# Altium schematic sheet icarus2.SchDoc
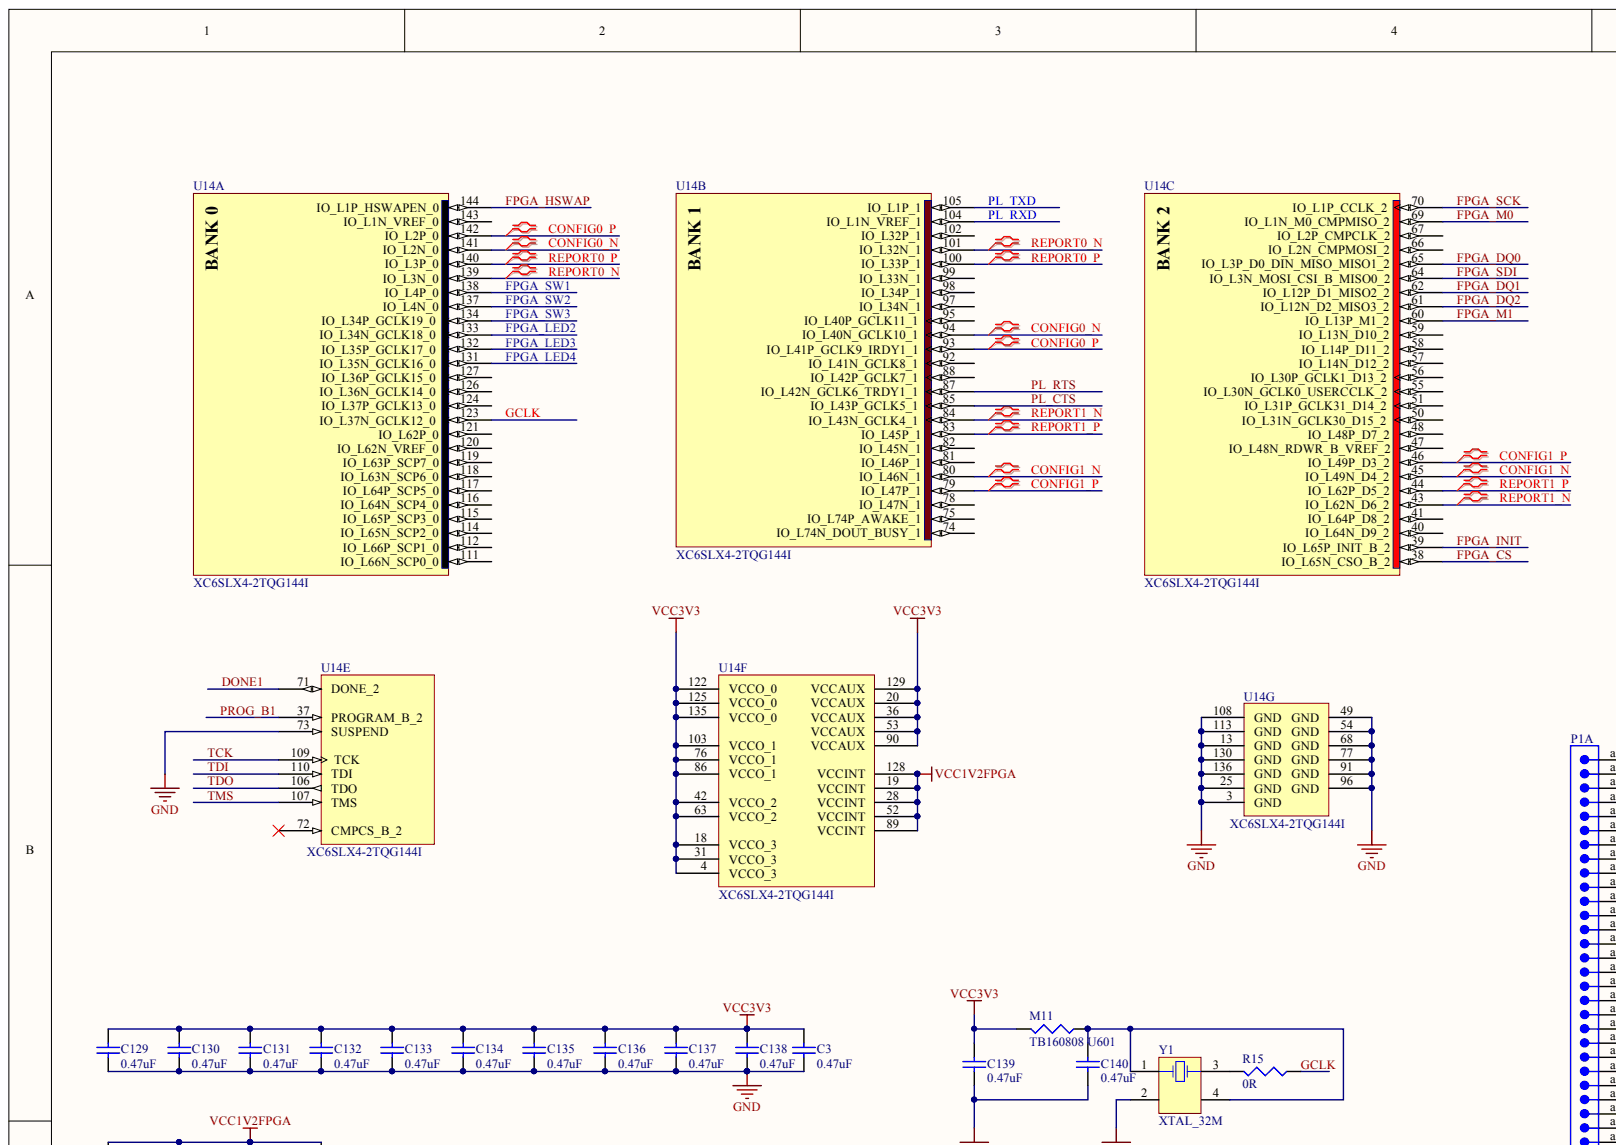
[diagram p0: icarus2.SchDoc, top left]
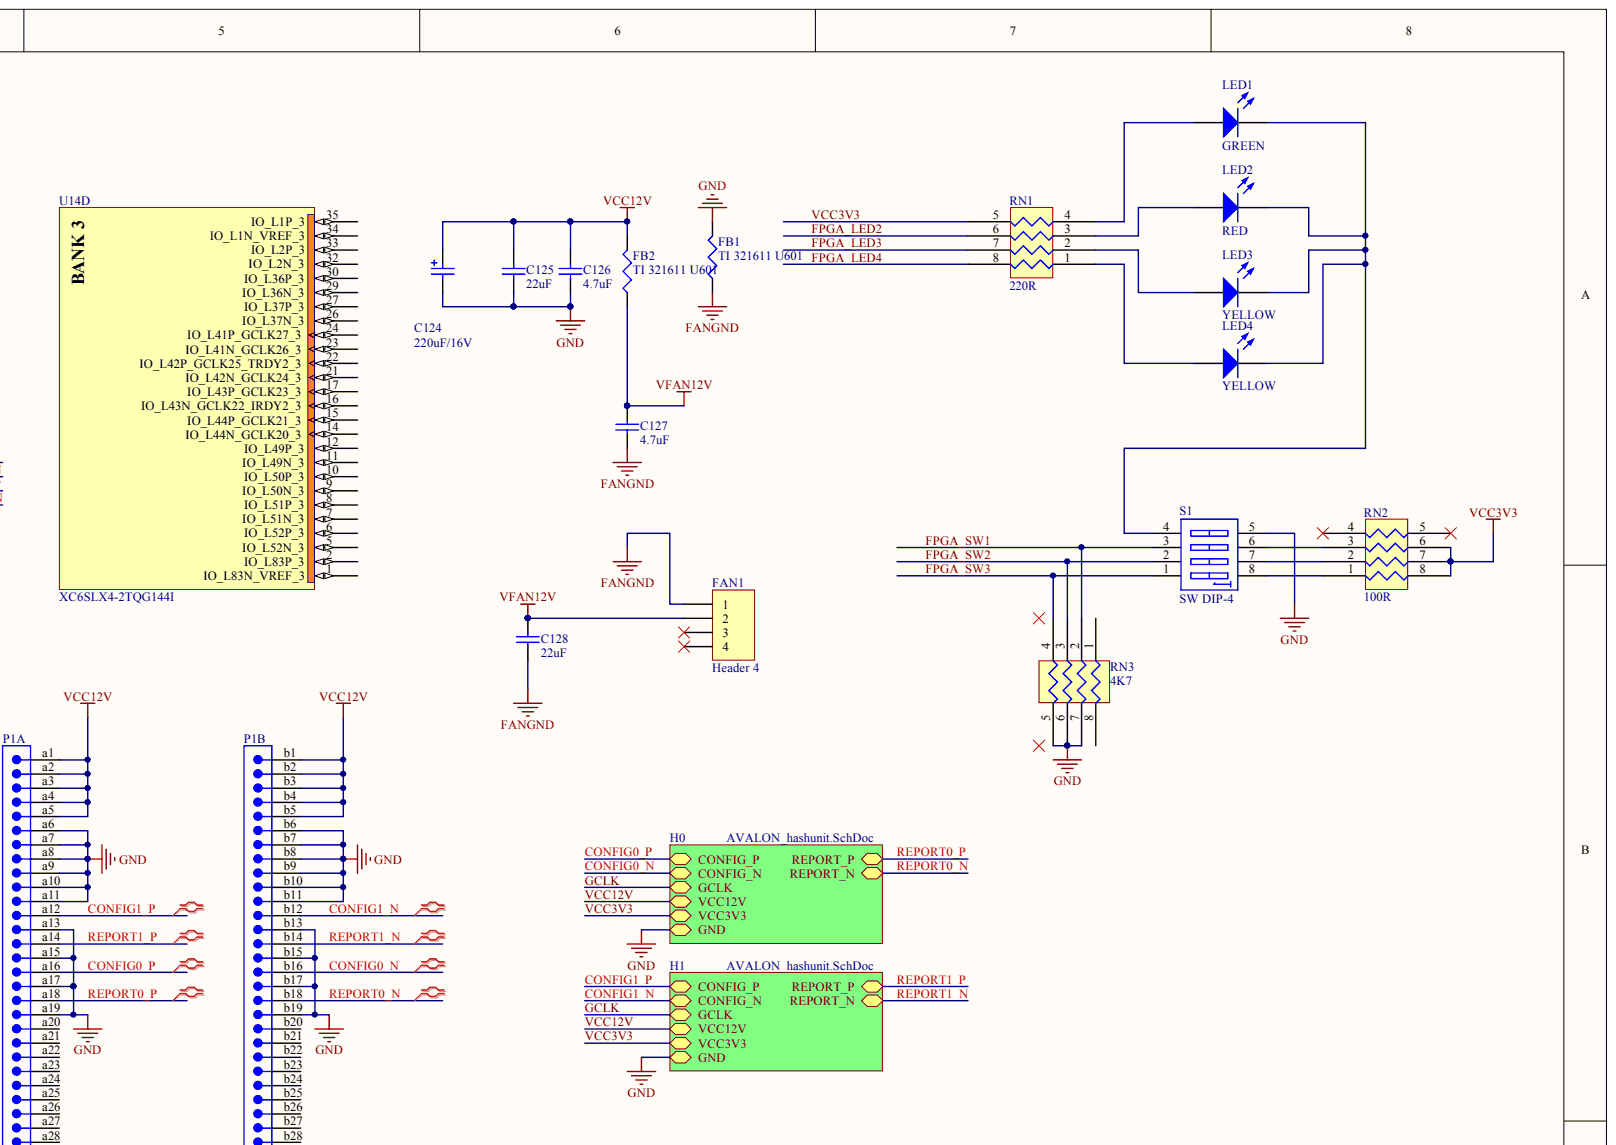
[diagram p1: icarus2.SchDoc, top right]
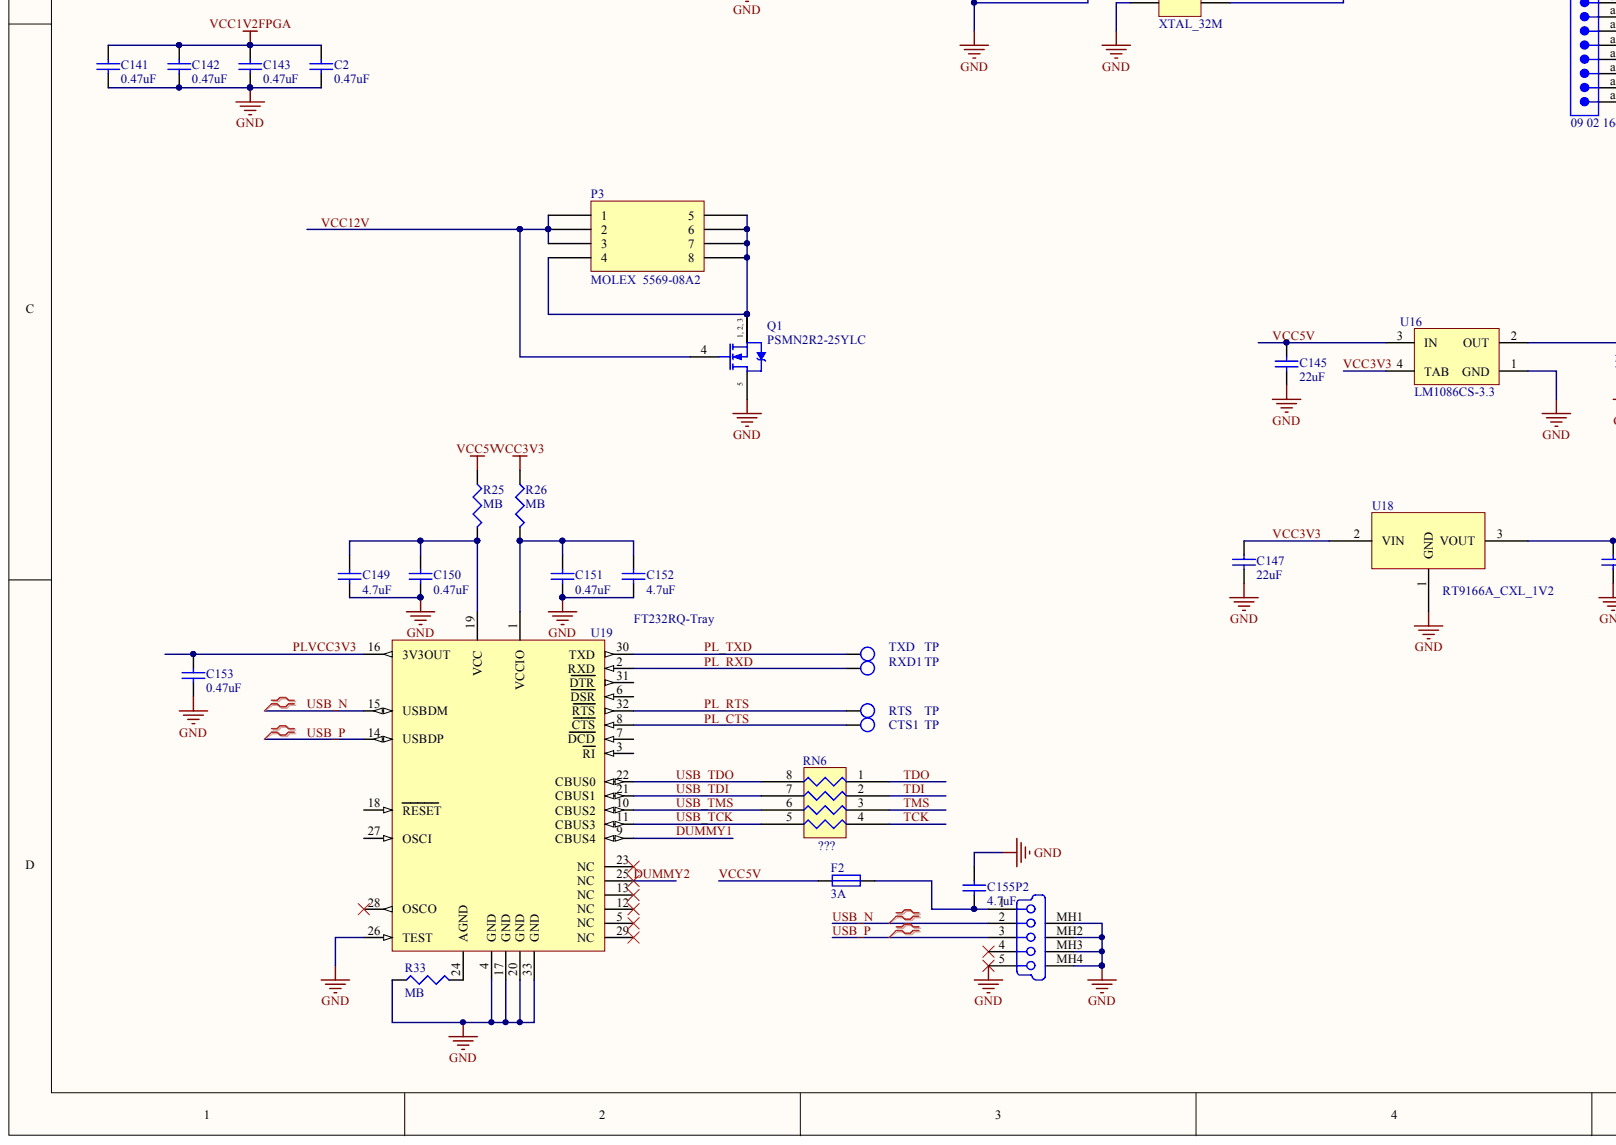
[diagram p2: icarus2.SchDoc, bottom left]
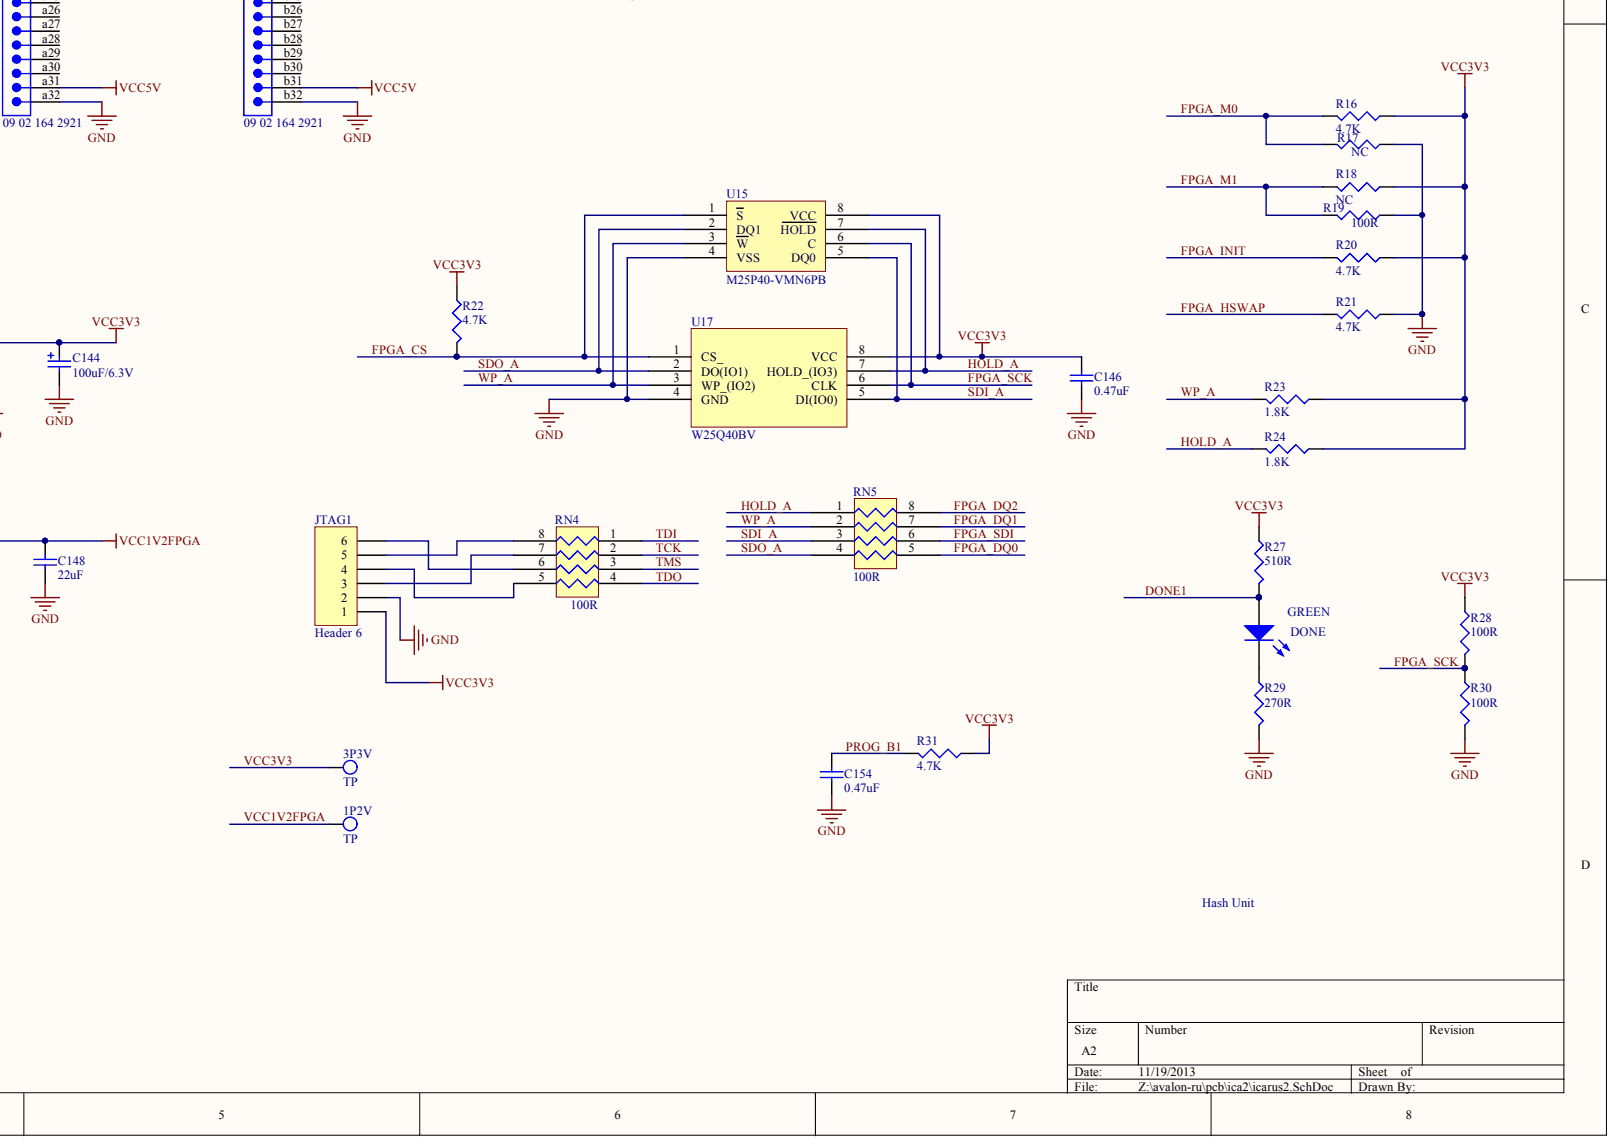
[diagram p3: icarus2.SchDoc, bottom right]

## Parts
1P2V  TP
3P3V  TP
C2  0.47uF
C3  0.47uF
C124  value 220uF/16V  (hidden comment: CPOL)
C125  22uF
C126  4.7uF
C127  4.7uF
C128  22uF
C129  0.47uF
C130  0.47uF
C131  0.47uF
C132  0.47uF
C133  0.47uF
C134  0.47uF
C135  0.47uF
C136  0.47uF
C137  0.47uF
C138  0.47uF
C139  0.47uF
C140  0.47uF
C141  0.47uF
C142  0.47uF
C143  0.47uF
C144  value 100uF/6.3V  (hidden comment: CTANT)
C145  22uF
C146  0.47uF
C147  22uF
C148  22uF
C149  4.7uF
C150  0.47uF
C151  0.47uF
C152  4.7uF
C153  0.47uF
C154  0.47uF
C155  4.7uF
CTS1  TP
DONE  GREEN
F2  value 3A  (hidden comment: P01OTS)
FAN1  Header 4
FB1  TI 321611 U601
FB2  TI 321611 U601
JTAG1  Header 6
LED1  GREEN
LED2  RED
LED3  YELLOW
LED4  YELLOW
M11  TB160808 U601
P1  09 02 164 2921  (parts A,B)
P2  mini USB connector  (value not drawn)
P3  MOLEX  5569-08A2
Q1  PSMN2R2-25YLC
R15  0R
R16  4.7K
R17  NC
R18  NC
R19  100R
R20  4.7K
R21  4.7K
R22  4.7K
R23  1.8K
R24  1.8K
R25  MB
R26  MB
R27  510R
R28  100R
R29  270R
R30  100R
R31  4.7K
R33  MB
RN1  220R
RN2  100R
RN3  4K7
RN4  100R
RN5  100R
RN6  ???
RTS  TP
RXD1  TP
S1  SW DIP-4
TXD  TP
U14  XC6SLX4-2TQG144I  (parts A,B,C,D,E,F,G)
U15  M25P40-VMN6PB
U16  LM1086CS-3.3
U17  W25Q40BV
U18  RT9166A_CXL_1V2
U19  FT232RQ-Tray
Y1  XTAL_32M

## Nets
FANGND: C127.1 C128.1 FAN1.1 FB1.1
GND: C2.2 C3.2 C124.1 C125.1 C126.1 C129.2 C130.2 C131.2 C132.2 C133.2 C134.2 C135.2 C136.2 C137.2 C138.2 C139.1 C140.1 C141.2 C142.2 C143.2 C144.1 C145.1 C146.1 C147.1 C148.1 C149.1 C150.1 C151.1 C152.1 C153.1 C154.2 C155.1 FB1.2 JTAG1.2 P1.a6 P1.a7 P1.a8 P1.a9 P1.a10 P1.a11 P1.a13 P1.a15 P1.a17 P1.a19 P1.a32 P1.b6 P1.b7 P1.b8 P1.b9 P1.b10 P1.b11 P1.b13 P1.b15 P1.b17 P1.b19 P1.b32 P2.5 P2.MH1 P2.MH2 P2.MH3 P2.MH4 Q1.5 R17.1 R19.1 R21.1 R29.2 R30.2 R33.1 RN3.5 RN3.6 RN3.7 S1.5 U14.3(GND) U14.13(GND) U14.25(GND) U14.49(GND) U14.54(GND) U14.68(GND) U14.73(SUSPEND) U14.77(GND) U14.91(GND) U14.96(GND) U14.108(GND) U14.113(GND) U14.130(GND) U14.136(GND) U15.4(VSS) U16.1(GND) U17.4(GND) U18.1 U19.4(GND) U19.17(GND) U19.20(GND) U19.26(TEST) U19.33(GND) Y1.2
VFAN12V: C127.2 C128.2 FAN1.2 FB2.1
CONFIG0_N: P1.b16 U14.94(IO_L40N_GCLK10_1) U14.141(IO_L2N_0)
CONFIG0_P: P1.a16 U14.93(IO_L41P_GCLK9_IRDY1_1) U14.142(IO_L2P_0)
CONFIG1_N: P1.b12 U14.45(IO_L49N_D4_2) U14.80(IO_L46N_1)
CONFIG1_P: P1.a12 U14.46(IO_L49P_D3_2) U14.79(IO_L47P_1)
DONE1: DONE.1 R27.2 U14.71(DONE_2)
DUMMY1: U19.9(CBUS4)
DUMMY2: U19.25(NC)
FPGA_CS: R22.2 U14.38(IO_L65N_CSO_B_2) U15.1(S) U17.1(CS_)
FPGA_DQ0: RN5.5 U14.65(IO_L3P_D0_DIN_MISO_MISO1_2)
FPGA_DQ1: RN5.7 U14.62(IO_L12P_D1_MISO2_2)
FPGA_DQ2: RN5.8 U14.61(IO_L12N_D2_MISO3_2)
FPGA_HSWAP: R21.2 U14.144(IO_L1P_HSWAPEN_0)
FPGA_INIT: R20.2 U14.39(IO_L65P_INIT_B_2)
FPGA_LED2: RN1.6 U14.133(IO_L34N_GCLK18_0)
FPGA_LED3: RN1.7 U14.132(IO_L35P_GCLK17_0)
FPGA_LED4: RN1.8 U14.131(IO_L35N_GCLK16_0)
FPGA_M0: R16.2 R17.2 U14.69(IO_L1N_M0_CMPMISO_2)
FPGA_M1: R18.2 R19.2 U14.60(IO_L13P_M1_2)
FPGA_SCK: R28.2 R30.1 U14.70(IO_L1P_CCLK_2) U15.6(C) U17.6(CLK)
FPGA_SDI: RN5.6 U14.64(IO_L3N_MOSI_CSI_B_MISO0_2)
FPGA_SW1: RN3.2 S1.3 U14.138(IO_L4P_0)
FPGA_SW2: RN3.3 S1.2 U14.137(IO_L4N_0)
FPGA_SW3: RN3.4 S1.1 U14.134(IO_L34P_GCLK19_0)
GCLK: R15.1 U14.123(IO_L37N_GCLK12_0)
HOLD_A: R24.2 RN5.1 U15.7(HOLD) U17.7(HOLD_(IO3))
PL_CTS: CTS1.1 U14.85(IO_L43P_GCLK5_1) U19.8(CTS)
PL_RTS: RTS.1 U14.87(IO_L42N_GCLK6_TRDY1_1) U19.32(RTS)
PL_RXD: RXD1.1 U14.104(IO_L1N_VREF_1) U19.2(RXD)
PL_TXD: TXD.1 U14.105(IO_L1P_1) U19.30(TXD)
PLVCC3V3: C153.2 U19.16(3V3OUT)
PROG_B1: C154.1 R31.2 U14.37(PROGRAM_B_2)
REPORT0_N: P1.b18 U14.101(IO_L32N_1) U14.139(IO_L3N_0)
REPORT0_P: P1.a18 U14.100(IO_L33P_1) U14.140(IO_L3P_0)
REPORT1_N: P1.b14 U14.43(IO_L62N_D6_2) U14.84(IO_L43N_GCLK4_1)
REPORT1_P: P1.a14 U14.44(IO_L62P_D5_2) U14.83(IO_L45P_1)
SDI_A: RN5.3 U15.5(DQ0) U17.5(DI(IO0))
SDO_A: RN5.4 U15.2(DQ1) U17.2(DO(IO1))
TCK: RN4.2 RN6.4 U14.109(TCK)
TDI: RN4.1 RN6.2 U14.110(TDI)
TDO: RN4.4 RN6.1 U14.106(TDO)
TMS: RN4.3 RN6.3 U14.107(TMS)
USB_N: P2.2 U19.15(USBDM)
USB_P: P2.3 U19.14(USBDP)
USB_TCK: RN6.5 U19.11(CBUS3)
USB_TDI: RN6.7 U19.21(CBUS1)
USB_TDO: RN6.8 U19.22(CBUS0)
USB_TMS: RN6.6 U19.10(CBUS2)
VCC1V2FPGA: 1P2V.1 C2.1 C141.1 C142.1 C143.1 C148.2 U14.19(VCCINT) U14.28(VCCINT) U14.52(VCCINT) U14.89(VCCINT) U14.128(VCCINT) U18.3
VCC3V3: 3P3V.1 C3.1 C129.1 C130.1 C131.1 C132.1 C133.1 C134.1 C135.1 C136.1 C137.1 C138.1 C139.2 C144.2 C146.2 C147.2 JTAG1.1 M11.2 R16.1 R18.1 R20.1 R22.1 R23.1 R24.1 R26.1 R27.1 R28.1 R31.1 RN1.5 RN2.6 RN2.7 RN2.8 U14.4(VCCO_3) U14.18(VCCO_3) U14.20(VCCAUX) U14.31(VCCO_3) U14.36(VCCAUX) U14.42(VCCO_2) U14.53(VCCAUX) U14.63(VCCO_2) U14.76(VCCO_1) U14.86(VCCO_1) U14.90(VCCAUX) U14.103(VCCO_1) U14.122(VCCO_0) U14.125(VCCO_0) U14.129(VCCAUX) U14.135(VCCO_0) U15.8(VCC) U16.2(OUT) U16.4(TAB) U17.8(VCC) U18.2
VCC5V: C145.2 F2.1 P1.a31 P1.b31 R25.1 U16.3(IN)
VCC12V: C124.2 C125.2 C126.2 FB2.2 P1.a1 P1.a2 P1.a3 P1.a4 P1.a5 P1.b1 P1.b2 P1.b3 P1.b4 P1.b5 P3.1 P3.2 P3.3 Q1.4
WP_A: R23.2 RN5.2 U15.3(W) U17.3(WP_(IO2))
NetC140_2: C140.2 M11.1 Y1.1 Y1.4
NetC149_2: C149.2 C150.2 R25.2 U19.19(VCC)
NetC151_2: C151.2 C152.2 R26.2 U19.1(VCCIO)
NetC155_2: C155.2 F2.2 P2.1
NetDONE_2: DONE.2 R29.1
NetFAN1_3: FAN1.3
NetFAN1_4: FAN1.4
NetJTAG1_3: JTAG1.3 RN4.7
NetJTAG1_4: JTAG1.4 RN4.5
NetJTAG1_5: JTAG1.5 RN4.8
NetJTAG1_6: JTAG1.6 RN4.6
NetLED1_1: LED1.1 RN1.4
NetLED1_2: LED1.2 LED2.2 LED3.2 LED4.2 S1.4
NetLED2_1: LED2.1 RN1.3
NetLED3_1: LED3.1 RN1.2
NetLED4_1: LED4.1 RN1.1
NetP1_a20: P1.a20
NetP1_a21: P1.a21
NetP1_a22: P1.a22
NetP1_a23: P1.a23
NetP1_a24: P1.a24
NetP1_a25: P1.a25
NetP1_a26: P1.a26
NetP1_a27: P1.a27
NetP1_a28: P1.a28
NetP1_a29: P1.a29
NetP1_a30: P1.a30
NetP1_b20: P1.b20
NetP1_b21: P1.b21
NetP1_b22: P1.b22
NetP1_b23: P1.b23
NetP1_b24: P1.b24
NetP1_b25: P1.b25
NetP1_b26: P1.b26
NetP1_b27: P1.b27
NetP1_b28: P1.b28
NetP1_b29: P1.b29
NetP1_b30: P1.b30
NetP2_4: P2.4
NetP3_4: P3.4 P3.5 P3.6 P3.7 P3.8 Q1.1 Q1.2 Q1.3
NetR15_2: R15.2 Y1.3
NetR33_2: R33.2 U19.24(AGND)
NetRN2_1: RN2.1 S1.8
NetRN2_2: RN2.2 S1.7
NetRN2_3: RN2.3 S1.6
NetRN2_4: RN2.4
NetRN2_5: RN2.5
NetRN3_1: RN3.1
NetRN3_8: RN3.8
NetU14_1: U14.1(IO_L83N_VREF_3)
NetU14_2: U14.2(IO_L83P_3)
NetU14_5: U14.5(IO_L52N_3)
NetU14_6: U14.6(IO_L52P_3)
NetU14_7: U14.7(IO_L51N_3)
NetU14_8: U14.8(IO_L51P_3)
NetU14_9: U14.9(IO_L50N_3)
NetU14_10: U14.10(IO_L50P_3)
NetU14_11: U14.11(IO_L49N_3)
NetU14_12: U14.12(IO_L49P_3)
NetU14_14: U14.14(IO_L44N_GCLK20_3)
NetU14_15: U14.15(IO_L44P_GCLK21_3)
NetU14_16: U14.16(IO_L43N_GCLK22_IRDY2_3)
NetU14_17: U14.17(IO_L43P_GCLK23_3)
NetU14_21: U14.21(IO_L42N_GCLK24_3)
NetU14_22: U14.22(IO_L42P_GCLK25_TRDY2_3)
NetU14_23: U14.23(IO_L41N_GCLK26_3)
NetU14_24: U14.24(IO_L41P_GCLK27_3)
NetU14_26: U14.26(IO_L37N_3)
NetU14_27: U14.27(IO_L37P_3)
NetU14_29: U14.29(IO_L36N_3)
NetU14_30: U14.30(IO_L36P_3)
NetU14_32: U14.32(IO_L2N_3)
NetU14_33: U14.33(IO_L2P_3)
NetU14_34: U14.34(IO_L1N_VREF_3)
NetU14_35: U14.35(IO_L1P_3)
NetU14_40: U14.40(IO_L64N_D9_2)
NetU14_41: U14.41(IO_L64P_D8_2)
NetU14_47: U14.47(IO_L48N_RDWR_B_VREF_2)
NetU14_48: U14.48(IO_L48P_D7_2)
NetU14_50: U14.50(IO_L31N_GCLK30_D15_2)
NetU14_51: U14.51(IO_L31P_GCLK31_D14_2)
NetU14_55: U14.55(IO_L30N_GCLK0_USERCCLK_2)
NetU14_56: U14.56(IO_L30P_GCLK1_D13_2)
NetU14_57: U14.57(IO_L14N_D12_2)
NetU14_58: U14.58(IO_L14P_D11_2)
NetU14_59: U14.59(IO_L13N_D10_2)
NetU14_66: U14.66(IO_L2N_CMPMOSI_2)
NetU14_67: U14.67(IO_L2P_CMPCLK_2)
NetU14_72: U14.72(CMPCS_B_2)
NetU14_74: U14.74(IO_L74N_DOUT_BUSY_1)
NetU14_75: U14.75(IO_L74P_AWAKE_1)
NetU14_78: U14.78(IO_L47N_1)
NetU14_81: U14.81(IO_L46P_1)
NetU14_82: U14.82(IO_L45N_1)
NetU14_88: U14.88(IO_L42P_GCLK7_1)
NetU14_92: U14.92(IO_L41N_GCLK8_1)
NetU14_95: U14.95(IO_L40P_GCLK11_1)
NetU14_97: U14.97(IO_L34N_1)
NetU14_98: U14.98(IO_L34P_1)
NetU14_99: U14.99(IO_L33N_1)
NetU14_102: U14.102(IO_L32P_1)
NetU14_111: U14.111(IO_L66N_SCP0_0)
NetU14_112: U14.112(IO_L66P_SCP1_0)
NetU14_114: U14.114(IO_L65N_SCP2_0)
NetU14_115: U14.115(IO_L65P_SCP3_0)
NetU14_116: U14.116(IO_L64N_SCP4_0)
NetU14_117: U14.117(IO_L64P_SCP5_0)
NetU14_118: U14.118(IO_L63N_SCP6_0)
NetU14_119: U14.119(IO_L63P_SCP7_0)
NetU14_120: U14.120(IO_L62N_VREF_0)
NetU14_121: U14.121(IO_L62P_0)
NetU14_124: U14.124(IO_L37P_GCLK13_0)
NetU14_126: U14.126(IO_L36N_GCLK14_0)
NetU14_127: U14.127(IO_L36P_GCLK15_0)
NetU14_143: U14.143(IO_L1N_VREF_0)
NetU19_3: U19.3(RI)
NetU19_5: U19.5(NC)
NetU19_6: U19.6(DSR)
NetU19_7: U19.7(DCD)
NetU19_12: U19.12(NC)
NetU19_13: U19.13(NC)
NetU19_18: U19.18(RESET)
NetU19_23: U19.23(NC)
NetU19_27: U19.27(OSCI)
NetU19_28: U19.28(OSCO)
NetU19_29: U19.29(NC)
NetU19_31: U19.31(DTR)

## Library data of the parts
P1 = (no symbol name): DrillSize: Corresponds to nominal value.; Published: 6-Oct-2005; DatasheetDocument: 02-Jun-2003; PackageDocument: 21-Jun-2000; PackageReference: 0902164x921; ComponentLink1Description: Manufacturer Link; ComponentLink1URL: http://www.harting.com/; ComponentLink2Description: Pinout Specification; ComponentLink2URL: 0902164x921; ComponentLink3Description: Packaging Specification; ComponentLink3URL: http://www.harkis.harting.com/_gds/pdf/TB_0902164x921_BL01_R28027.pdf; Publisher: Altium Limited
P2 = (no symbol name): PCB_Layout: Complies with Manufacturer's Recommendation.; Published: 21-Sep-2007; DatasheetVersion: revA, May-2004; PackageVersion: May-2004; PackageReference: 481900001; PackageDescription: USB Mini-B, Sinking Type, SM; 5 Position; Right Angle, Body 11.45 x 11.8 x 3.9 mm (LxWxH); ComponentLink1Description: Manufacturer Link; ComponentLink1URL: http://www.molex.com/; ComponentLink2Description: Datasheet; ComponentLink2URL: http://www.molex.com/cmc_upload/0/000/895/958/SD-48190-001RevA.pdf; Publisher: Altium Limited
U16 = (no symbol name): PartNumber: LM1086CS-2.5; Manufacturer: National Semiconductor; PackageTopMarking: NSUZXYTTE#*LM1086*CS-2.5; PowerWise: N; CompType: LDO IC; Published: 4-Dec-2008; DatasheetVersion: Jun-2005; PackageVersion: revF, Apr-2005; PackageReference: TS3B; PackageDescription: TO-263, Molded, .400 x .390 x .180in, 3 Lead, .1in Pitch, Surface Mount; Supplier 1: Farnell; Supplier Part Number 1: 7614068; RoHS: N; PinCount: 3; Code_JEDEC: MO-169-AA; ComponentLink1Description: LM1086 Datasheet; ComponentLink1URL: http://www.national.com/ds/LM/LM1086.pdf; ComponentLink2Description: LM1086 Web Page; ComponentLink2URL: http://www.national.com/pf/LM/LM1086.html; ComponentLink3Description: TS3B Package Page; ComponentLink3URL: http://www.national.com/packaging/folders/ts3b.html; Publisher: Altium Limited
Y1 = (no symbol name): Note: PCB layout complies with manufacturer's recommendation.; Published: 17-Jul-2002; DatasheetDocument: 9-Sep-1999; PackageDocument: 9-Sep-1999; PackageReference: 2SMX; ComponentLink1Description: Manufacturer Link; ComponentLink1URL: http://www.cmac.com/mt/; Publisher: Altium Limited
1P2V 3P3V C2 C3 C124-C155 CTS1 DONE F2 FAN1 FB1 FB2 JTAG1 LED1-LED4 M11 P3 Q1 R15-R31 R33 RN1-RN6 RTS RXD1 S1 TXD U14 U15 U17-U19 = (no symbol name)
  C2 C3 C129-C143 C146 C150 C151 C153 C154: Published: 8-Jun-2000; LatestRevisionDate: 29-May-2009; LatestRevisionNote: IPC-7351 Footprint Added.; PackageReference: C1206; PackageDescription: Chip Capacitor; Value: 0.47uF
  C125 C128 C145 C147 C148: Published: 8-Jun-2000; LatestRevisionDate: 29-May-2009; LatestRevisionNote: IPC-7351 Footprint Added.; PackageReference: C1206; PackageDescription: Chip Capacitor; Value: 22uF
  C126 C127 C149 C152 C155: Published: 8-Jun-2000; LatestRevisionDate: 29-May-2009; LatestRevisionNote: IPC-7351 Footprint Added.; PackageReference: C1206; PackageDescription: Chip Capacitor; Value: 4.7uF
  DONE LED1-LED4: Published: 8-Jun-2000; LatestRevisionDate: 17-Dec-2003; LatestRevisionNote: PCB Footprint replaced.; DatasheetVersion: 16-Apr-2002; PackageVersion: 16-Apr-2002; PackageReference: 3.5X2.8X1.9; PackageDescription: SM LED; 2 C-Bend Leads; Body 3.5 x 2.8 x 1.9 mm, inc leads (LxWxH typ)
  FAN1 JTAG1: LatestRevisionDate: 17-Jul-2002; LatestRevisionNote: Re-released for DXP Platform.
  FB1 FB2: Published: 8-Jun-2000; LatestRevisionDate: 29-May-2009; LatestRevisionNote: IPC-7351 Footprint Added.; PackageReference: J1-0603; PackageDescription: Chip Resistor; Value: TI 321611 U601
  M11: Published: 8-Jun-2000; LatestRevisionDate: 29-May-2009; LatestRevisionNote: IPC-7351 Footprint Added.; PackageReference: J1-0603; PackageDescription: Chip Resistor; Value: TB160808 U601
  R15: Published: 8-Jun-2000; LatestRevisionDate: 29-May-2009; LatestRevisionNote: IPC-7351 Footprint Added.; PackageReference: J1-0603; PackageDescription: Chip Resistor; Value: 0R
  R16 R20-R22 R31: Published: 8-Jun-2000; LatestRevisionDate: 29-May-2009; LatestRevisionNote: IPC-7351 Footprint Added.; PackageReference: J1-0603; PackageDescription: Chip Resistor; Value: 4.7K
  R17 R18: Published: 8-Jun-2000; LatestRevisionDate: 29-May-2009; LatestRevisionNote: IPC-7351 Footprint Added.; PackageReference: J1-0603; PackageDescription: Chip Resistor; Value: NC
  R19 R28 R30: Published: 8-Jun-2000; LatestRevisionDate: 29-May-2009; LatestRevisionNote: IPC-7351 Footprint Added.; PackageReference: J1-0603; PackageDescription: Chip Resistor; Value: 100R
  R23 R24: Published: 8-Jun-2000; LatestRevisionDate: 29-May-2009; LatestRevisionNote: IPC-7351 Footprint Added.; PackageReference: J1-0603; PackageDescription: Chip Resistor; Value: 1.8K
  R25 R26 R33: Published: 8-Jun-2000; LatestRevisionDate: 29-May-2009; LatestRevisionNote: IPC-7351 Footprint Added.; PackageReference: J1-0603; PackageDescription: Chip Resistor; Value: MB
  R27: Published: 8-Jun-2000; LatestRevisionDate: 29-May-2009; LatestRevisionNote: IPC-7351 Footprint Added.; PackageReference: J1-0603; PackageDescription: Chip Resistor; Value: 510R
  R29: Published: 8-Jun-2000; LatestRevisionDate: 29-May-2009; LatestRevisionNote: IPC-7351 Footprint Added.; PackageReference: J1-0603; PackageDescription: Chip Resistor; Value: 270R
  S1: Published: 24-Mar-1999; LatestRevisionDate: 29-May-2009; LatestRevisionNote: IPC-7351 Footprint Added.; PackageVersion: Dec-2002; PackageReference: SO8; PackageDescription: 8-Pin Small Outline Integrated Circuit 1.27 mm Pitch; Code_JEDEC: MS-012-AA; Code_IPC: SOIC127P600-8
  U14: Published: 1-Jul-2010; DatasheetVersion: ver1.6, Nov-2010; PackageVersion: ver1.2, Jun-2004; PackageReference: TQG144; PackageDescription: 144-Pin Thin Quad Flat Pack (TQG144) - Pb-Free; Supplier 1: Digi-Key; Supplier Part Number 1: XC6SLX4-2TQG144I-ND; Code_JEDEC: MS-026-BFB; ComponentLink1Description: Manufacturer Link; ComponentLink1URL: http://www.xilinx.com; ComponentLink2Description: Spartan-6 Datasheet; ComponentLink2URL: http://www.xilinx.com/support/documentation/data_sheets/ds160.pdf; ComponentLink3Description: Spartan-6 Documents; ComponentLink3URL: http://www.xilinx.com/support/documentation/spartan-6.htm; ComponentLink4Description: Xilinx.com Home; ComponentLink4URL: http://www.xilinx.com
  U19: Published: 19-Aug-2010; DatasheetVersion: ver 2.07, Jul-2010; PackageVersion: 2010; PackageReference: PQFN5X5-32; PackageDescription: 32-Pin Quad Flat No-Lead 0.5 mm Pitch, Pullback, 3.2 x 3.2 mm Exposed Pad; ComponentLink1Description: Manufacturer Link; ComponentLink1URL: http://www.ftdichip.com/Products/ICs/FT232R.htm; ComponentLink2Description: Datasheet; ComponentLink2URL: http://www.ftdichip.com/Support/Documents/DataSheets/ICs/DS_FT232R.pdf
  C2 C3 C125-C143 C145-C155 DONE FAN1 FB1 FB2 JTAG1 LED1-LED4 M11 R15-R31 R33 S1 U14 U19: Publisher: Altium Limited
